# Altium schematic sheet FlockLab.SchDoc(FlockLab)
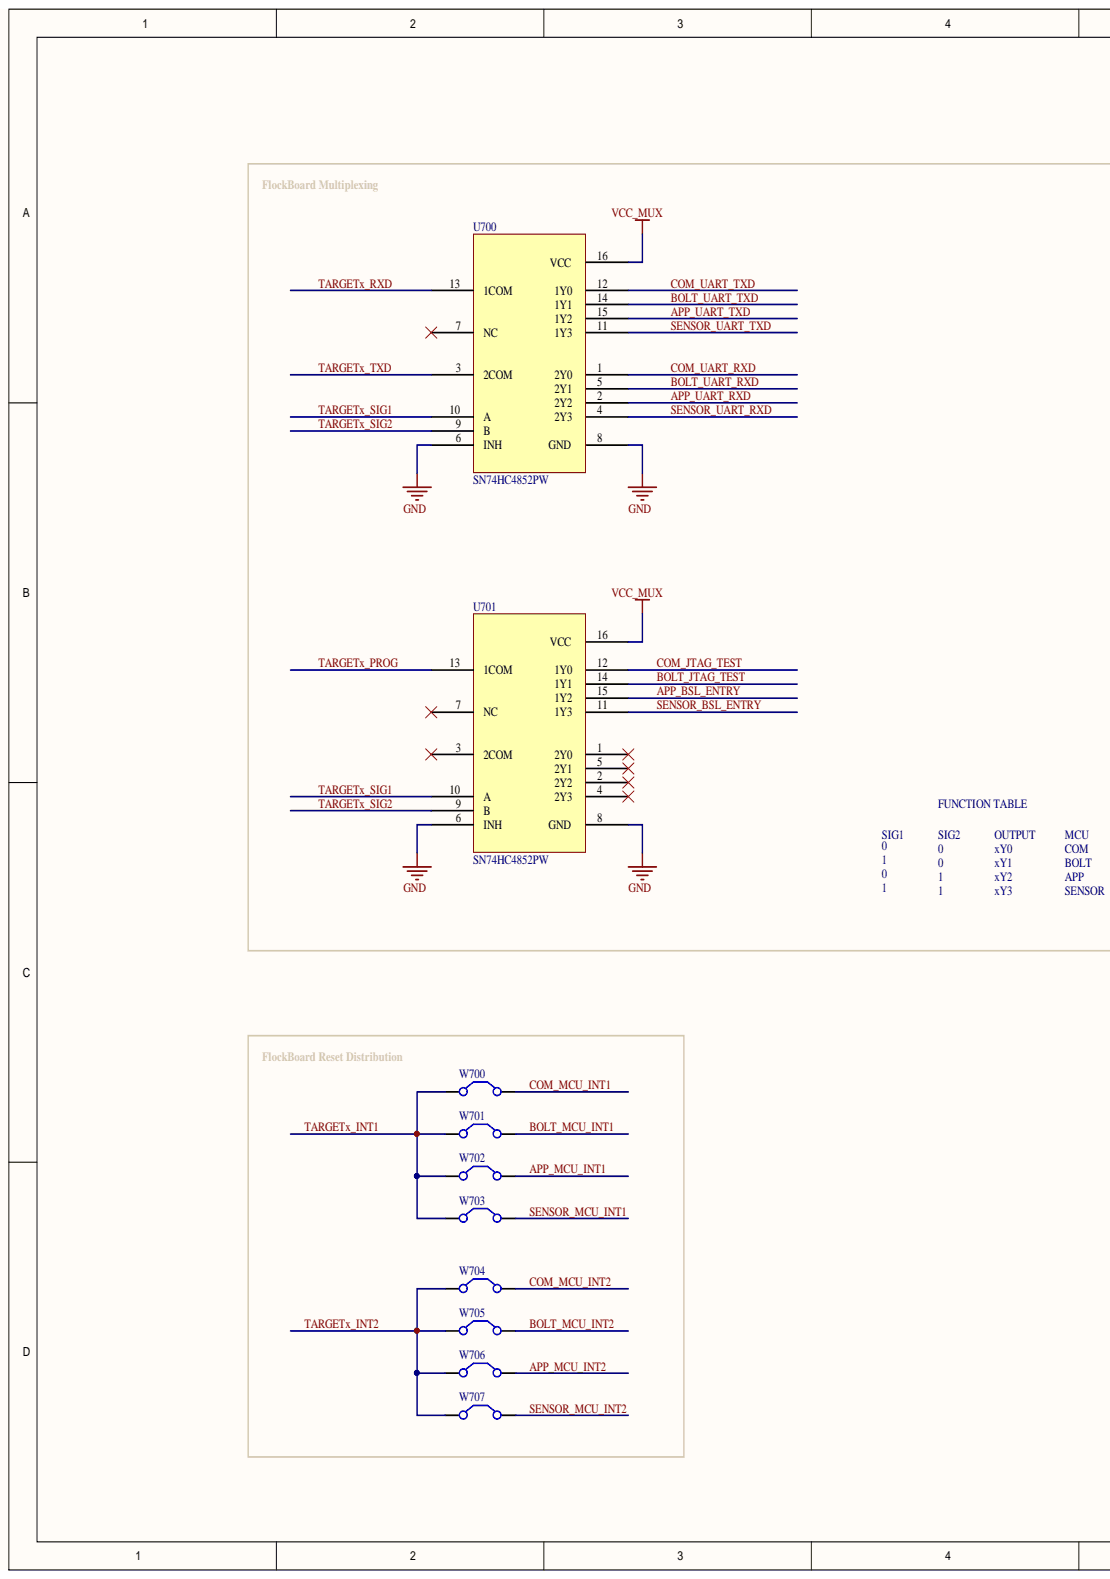
[diagram p0: FlockLab.SchDoc, left]
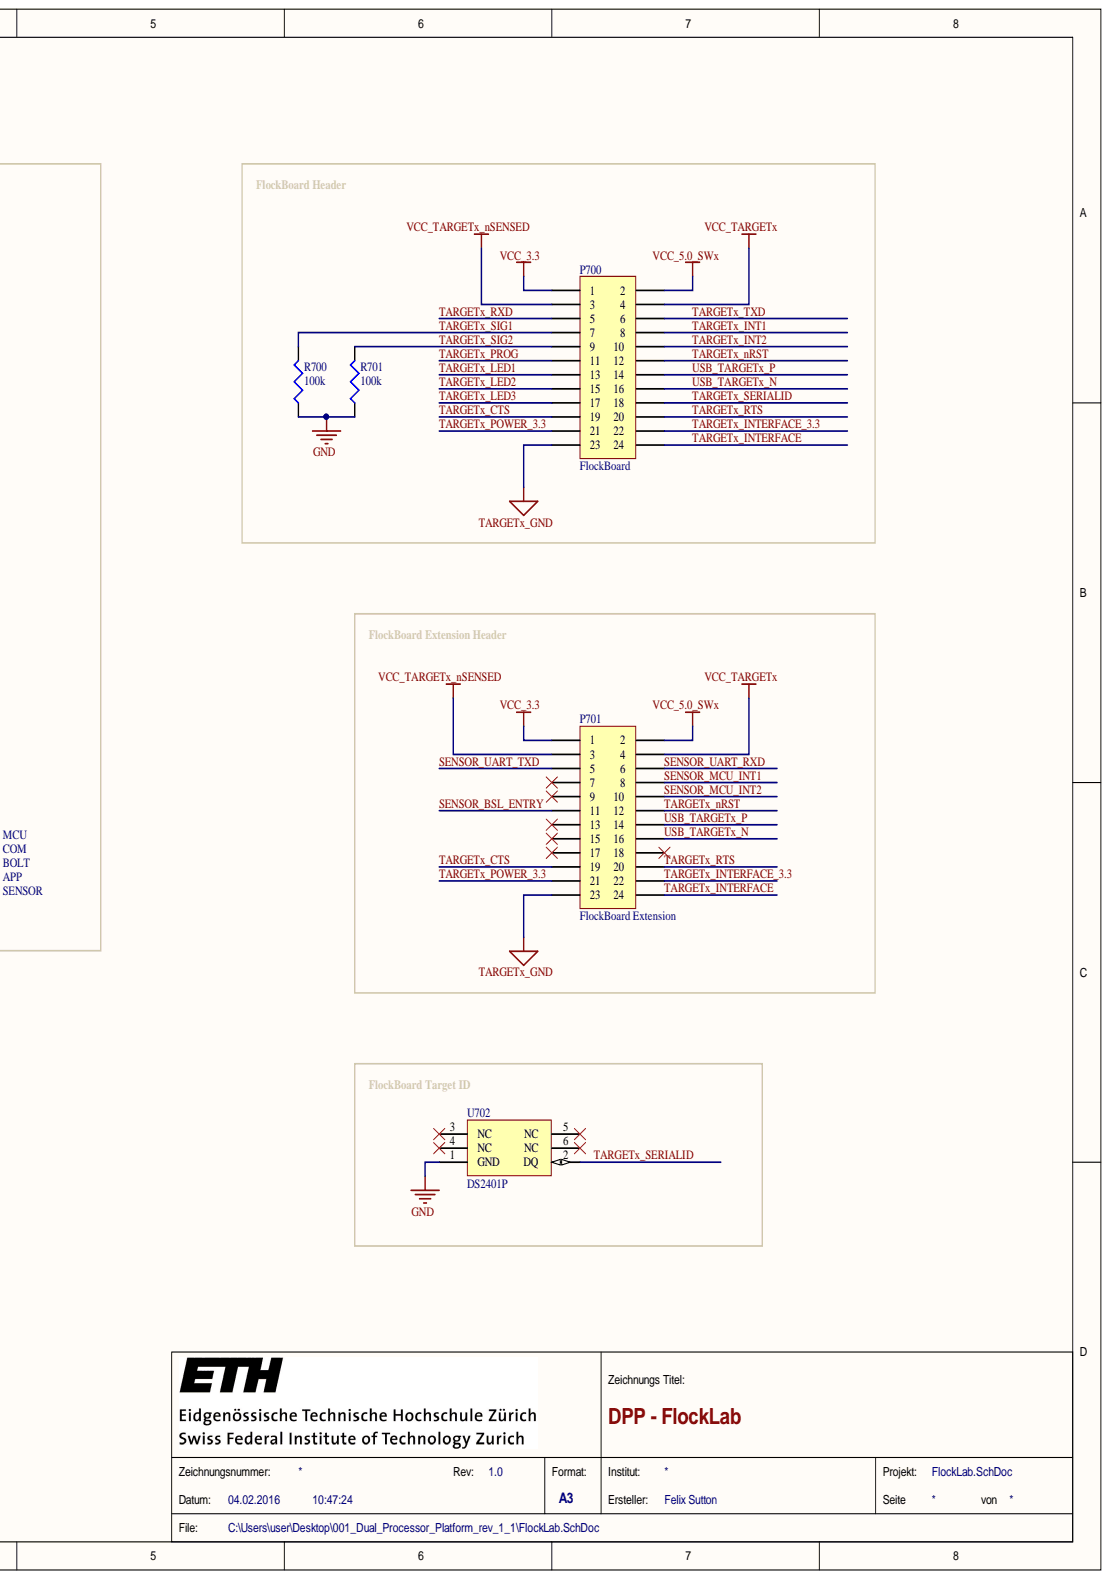
[diagram p1: FlockLab.SchDoc, right]

## Parts
P700  Function: FlockBoard  [HDR2X12]  (hidden comment: Header 12X2)
P701  Function: FlockBoard Extension  [HDR2X12]  (hidden comment: Header 12X2)
R700  100k  [RESC1005N]
R701  100k  [RESC1005N]
U700  SN74HC4852PW  [PDSO_G16]
U701  SN74HC4852PW  [PDSO_G16]
U702  DS2401P  [TSOC6]
W700  (no value)  [1X2_127_HDR]
W701  (no value)  [1X2_127_HDR]
W702  (no value)  [1X2_127_HDR]
W703  (no value)  [1X2_127_HDR]
W704  (no value)  [1X2_127_HDR]
W705  (no value)  [1X2_127_HDR]
W706  (no value)  [1X2_127_HDR]
W707  (no value)  [1X2_127_HDR]

## Nets
GND: R700.1 R701.1 U700.6(INH) U700.8(GND) U701.6(INH) U701.8(GND) U702.1(GND)
TARGETX_GND: P700.23 P701.23
VCC_3.3: P700.1 P701.1
VCC_5.0_SWX: P700.2 P701.2
VCC_MUX: U700.16(VCC) U701.16(VCC)
VCC_TARGETX: P700.4 P701.4
VCC_TARGETX_NSENSED: P700.3 P701.3
APP_BSL_ENTRY: U701.15(1Y2)
APP_MCU_INT1: W702.2
APP_MCU_INT2: W706.2
APP_UART_RXD: U700.2(2Y2)
APP_UART_TXD: U700.15(1Y2)
BOLT_JTAG_TEST: U701.14(1Y1)
BOLT_MCU_INT1: W701.2
BOLT_MCU_INT2: W705.2
BOLT_UART_RXD: U700.5(2Y1)
BOLT_UART_TXD: U700.14(1Y1)
COM_JTAG_TEST: U701.12(1Y0)
COM_MCU_INT1: W700.2
COM_MCU_INT2: W704.2
COM_UART_RXD: U700.1(2Y0)
COM_UART_TXD: U700.12(1Y0)
SENSOR_BSL_ENTRY: P701.11 U701.11(1Y3)
SENSOR_MCU_INT1: P701.8 W703.2
SENSOR_MCU_INT2: P701.10 W707.2
SENSOR_UART_RXD: P701.6 U700.4(2Y3)
SENSOR_UART_TXD: P701.5 U700.11(1Y3)
TARGETX_CTS: P700.19 P701.19
TARGETX_INT1: P700.8 W700.1 W701.1 W702.1 W703.1
TARGETX_INT2: P700.10 W704.1 W705.1 W706.1 W707.1
TARGETX_INTERFACE: P700.24 P701.24
TARGETX_INTERFACE_3.3: P700.22 P701.22
TARGETX_LED1: P700.13
TARGETX_LED2: P700.15
TARGETX_LED3: P700.17
TARGETX_NRST: P700.12 P701.12
TARGETX_POWER_3.3: P700.21 P701.21
TARGETX_PROG: P700.11 U701.13(1COM)
TARGETX_RTS: P700.20 P701.20
TARGETX_RXD: P700.5 U700.13(1COM)
TARGETX_SERIALID: P700.18 U702.2(DQ)
TARGETX_SIG1: P700.7 R700.2 U700.10(A) U701.10(A)
TARGETX_SIG2: P700.9 R701.2 U700.9(B) U701.9(B)
TARGETX_TXD: P700.6 U700.3(2COM)
USB_TARGETX_N: P700.16 P701.16
USB_TARGETX_P: P700.14 P701.14

Not connected: P701 7 9 13 15 17 18; U700 7(NC); U701 1(2Y0) 2(2Y2) 3(2COM) 4(2Y3) 5(2Y1) 7(NC); U702 3(NC) 4(NC) 5(NC) 6(NC)

## Library data of the parts
P700 P701 = Header 12X2: Header, 12-Pin, Dual row; Component Kind: Standard; Library Name: PermaSense.SchLib
R700 R701 = Res3: Resistor; Component Kind: Standard; LatestRevisionDate: 29-May-2009; LatestRevisionNote: IPC-7351 Footprint Added.; Library Name: Miscellaneous Devices.IntLib; PackageDescription: Chip Resistor; PackageReference: J1-0603; Published: 8-Jun-2000; Publisher: Altium Limited
U700 U701 = SN74HC4852PW: TI Dual 4:1 Analog Multiplexer/Demultiplexer; Component Kind: Standard; Library Name: Felix_Component_Library.SchLib
U702 = DS2401P: Silicon Serial Number; Component Kind: Standard; Library Name: PermaSense.SchLib
W700-W707 = Jumper: Jumper Wire; Component Kind: Net Tie; LatestRevisionDate: 17-Jul-2002; LatestRevisionNote: Re-released for DXP Platform.; Library Name: Miscellaneous Devices.IntLib; PackageReference: RAD-0.2; Published: 24-Mar-1999; Publisher: Altium Limited
